# Altium schematic sheet Bolt.SchDoc
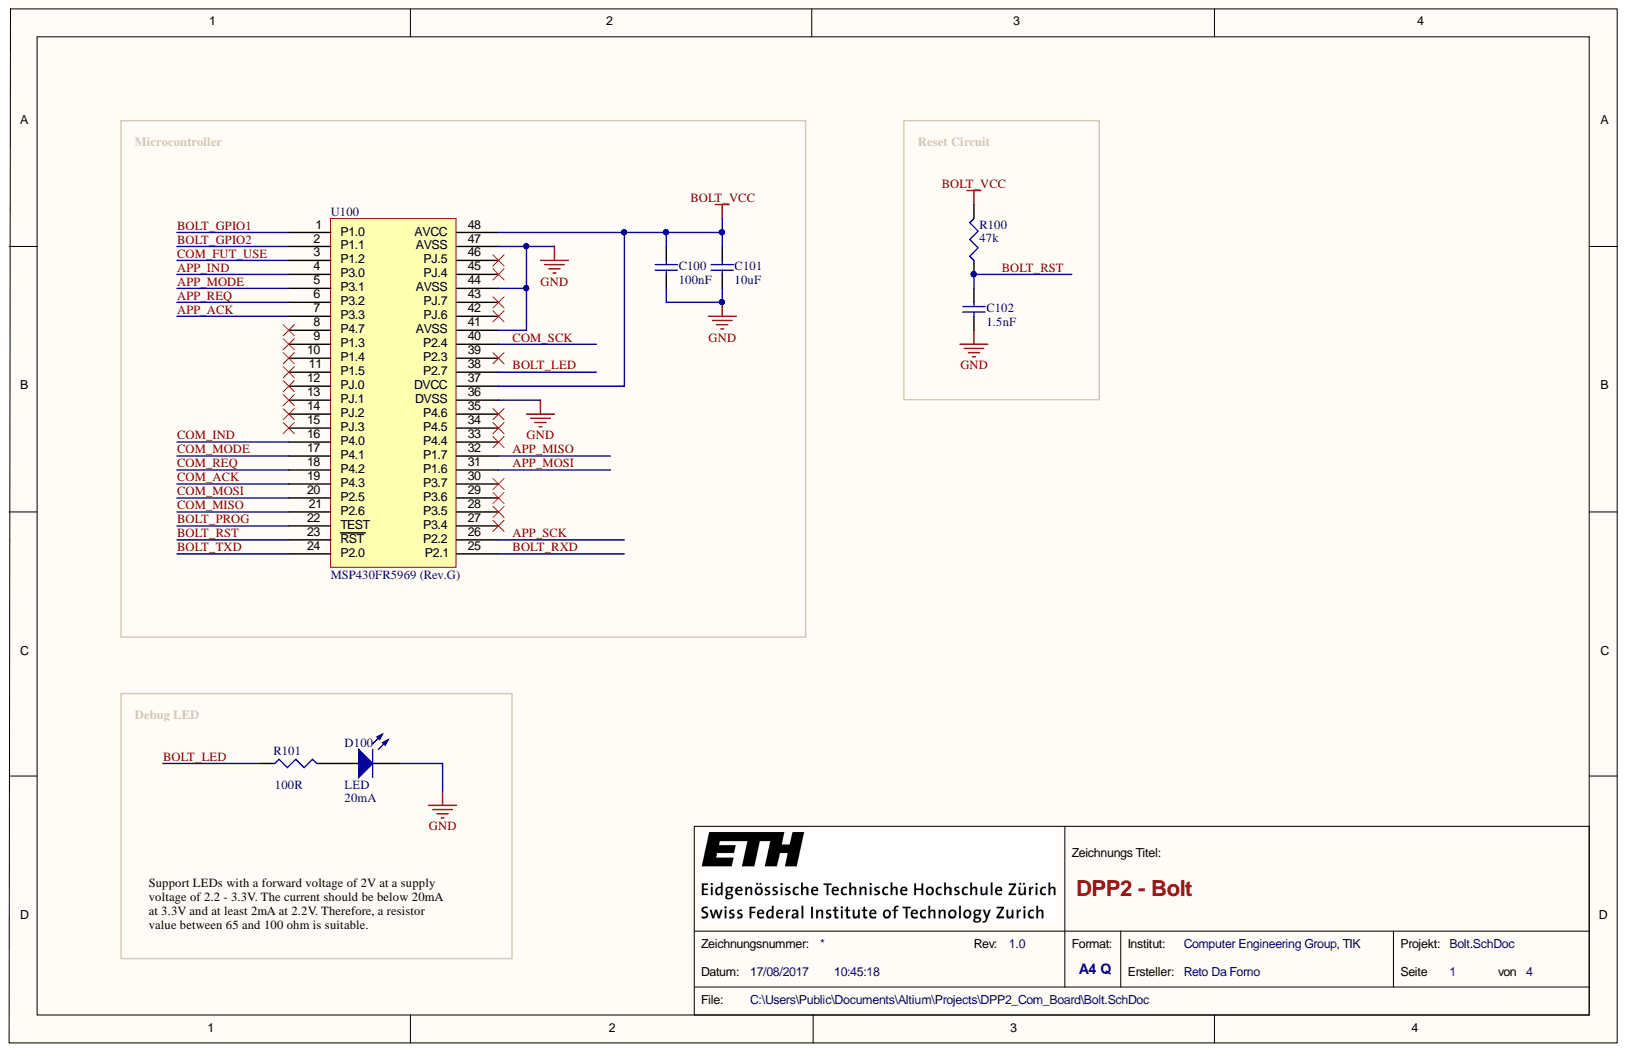
[diagram p0: Bolt.SchDoc]

## Parts
C100  100nF  [0402_N]
C101  10uF  [0402_N]
C102  1.5nF  [0402_N]
D100  LED  If: 20mA  [0603_LED2]
R100  47k  [0402_N]
R101  100R  [0402_N]
U100  MSP430FR5969 (Rev.G)  [RGZ_S_PVQFN_N48]

## Nets
BOLT_VCC: C100.1 C101.1 R100.2 U100.37(DVCC) U100.48(AVCC)
GND: C100.2 C101.2 C102.2 D100.2 U100.0 U100.36(DVSS) U100.41(AVSS) U100.44(AVSS) U100.47(AVSS)
APP_ACK: U100.7(P3.3)
APP_IND: U100.4(P3.0)
APP_MISO: U100.32(P1.7)
APP_MODE: U100.5(P3.1)
APP_MOSI: U100.31(P1.6)
APP_REQ: U100.6(P3.2)
APP_SCK: U100.26(P2.2)
BOLT_GPIO1: U100.1(P1.0)
BOLT_GPIO2: U100.2(P1.1)
BOLT_LED: R101.1 U100.38(P2.7)
BOLT_PROG: U100.22(TEST)
BOLT_RST: C102.1 R100.1 U100.23(RST)
BOLT_RXD: U100.25(P2.1)
BOLT_TXD: U100.24(P2.0)
COM_ACK: U100.19(P4.3)
COM_FUT_USE: U100.3(P1.2)
COM_IND: U100.16(P4.0)
COM_MISO: U100.21(P2.6)
COM_MODE: U100.17(P4.1)
COM_MOSI: U100.20(P2.5)
COM_REQ: U100.18(P4.2)
COM_SCK: U100.40(P2.4)
NetD100_1: D100.1 R101.2

Not connected: U100 8(P4.7) 9(P1.3) 10(P1.4) 11(P1.5) 12(PJ.0) 13(PJ.1) 14(PJ.2) 15(PJ.3) 27(P3.4) 28(P3.5) 29(P3.6) 30(P3.7) 33(P4.4) 34(P4.5) 35(P4.6) 39(P2.3) 42(PJ.6) 43(PJ.7) 45(PJ.4) 46(PJ.5)

## Library data of the parts
C100 = Cap: Capacitor; Manufacturer: Murata; PartNumber: GRM155R71C104KA88J; Component Kind: Standard; LatestRevisionDate: 17-Jul-2002; LatestRevisionNote: Re-released for DXP Platform.; Library Name: Miscellaneous Devices.IntLib; PackageReference: RAD-0.3; Published: 8-Jun-2000; Publisher: Altium Limited
C101 = Cap: Capacitor; Manufacturer: Murata; PartNumber: GRM155R60G106ME44D; Component Kind: Standard; LatestRevisionDate: 17-Jul-2002; LatestRevisionNote: Re-released for DXP Platform.; Library Name: Miscellaneous Devices.IntLib; PackageReference: RAD-0.3; Published: 8-Jun-2000; Publisher: Altium Limited
C102 = Cap: Capacitor; Manufacturer: Murata; PartNumber: GRM155R71H152JA01D; Component Kind: Standard; LatestRevisionDate: 17-Jul-2002; LatestRevisionNote: Re-released for DXP Platform.; Library Name: Miscellaneous Devices.IntLib; PackageReference: RAD-0.3; Published: 8-Jun-2000; Publisher: Altium Limited
D100 = LED0: Typical INFRARED GaAs LED; Manufacturer: Wurth Electronics; PartNumber: 150060VS75000; Vf: 2V; Component Kind: Standard; Library Name: Miscellaneous Devices.IntLib; Manufacturer2: Kingbright; PartNumber2: APT16081608CGKC
R100 = Res3: Resistor; Manufacturer: Yageo; PartNumber: RC0402FR-0747KL; Component Kind: Standard; LatestRevisionDate: 29-May-2009; LatestRevisionNote: IPC-7351 Footprint Added.; Library Name: Miscellaneous Devices.IntLib; PackageDescription: Chip Resistor; PackageReference: J1-0603; Published: 8-Jun-2000; Publisher: Altium Limited
R101 = Res3: Resistor; Manufacturer: Yageo; PartNumber: RC0402FR-07100RL; Component Kind: Standard; LatestRevisionDate: 29-May-2009; LatestRevisionNote: IPC-7351 Footprint Added.; Library Name: Miscellaneous Devices.IntLib; PackageDescription: Chip Resistor; PackageReference: J1-0603; Published: 8-Jun-2000; Publisher: Altium Limited
U100 = MSP430FR5969: TI MSP430FR5969 MCU, 64k FRAM; Component Kind: Standard; Name: MSP430FR5969 (Rev.G); Library Name: Felix_Component_Library.SchLib
